# Altium schematic sheet Driver.SchDoc
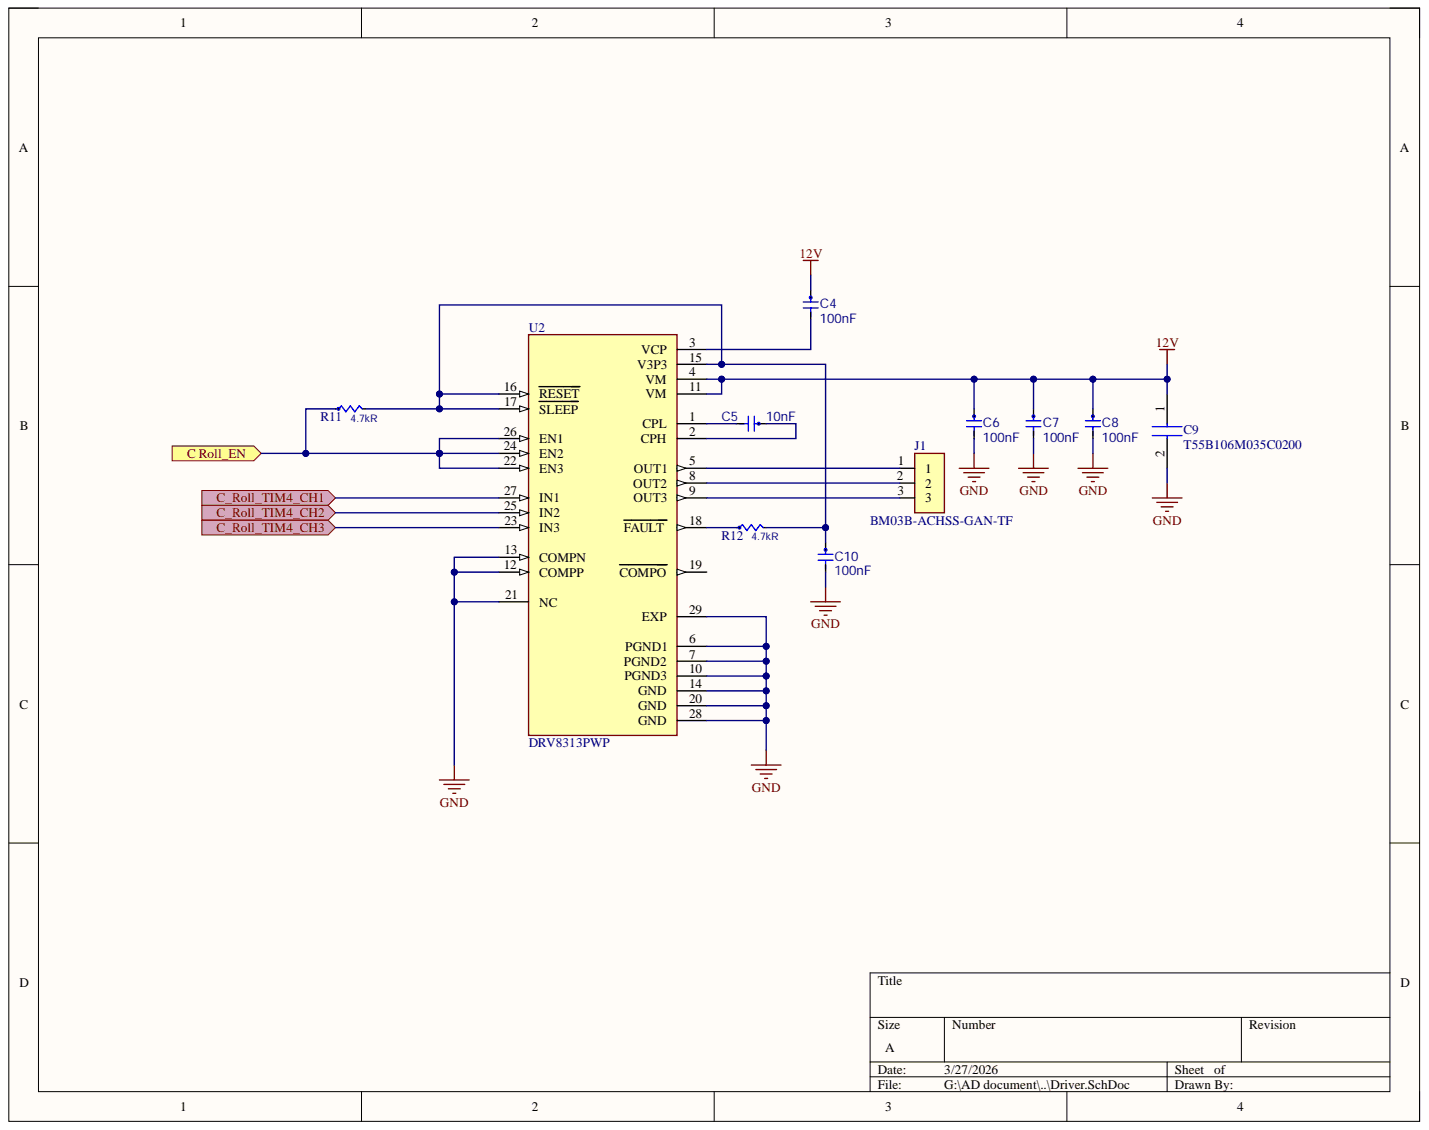
[diagram p0: Driver.SchDoc]

## Parts
C4  Capacitance: 100nF  [CAP0603]  (hidden comment: C0603C104M5RACTU)
C5  Capacitance: 10nF  [CAP0603]  (hidden comment: C0603C103M5RACTU)
C6  Capacitance: 100nF  [CAP0603]  (hidden comment: C0603C104M5RACTU)
C7  Capacitance: 100nF  [CAP0603]  (hidden comment: C0603C104M5RACTU)
C8  Capacitance: 100nF  [CAP0603]  (hidden comment: C0603C104M5RACTU)
C9  T55B106M035C0200  [T55B106M035C0200]
C10  Capacitance: 100nF  [CAP0603]  (hidden comment: C0603C104M5RACTU)
J1  BM03B-ACHSS-GAN-TF  [BM03B-ACHSS-GAN-TF]
R11  Resistance: 4.7kR  [RES0603]  (hidden comment: RC0603JR-074K7L)
R12  Resistance: 4.7kR  [RES0603]  (hidden comment: RC0603JR-074K7L)
U2  DRV8313PWP  [DRV8313PWP]

## Ports
C Roll_EN, C_Roll_TIM4_CH1, C_Roll_TIM4_CH2, C_Roll_TIM4_CH3

## Nets
12V: C4.1 C6.1 C7.1 C8.1 C9.1 U2.4(VM) U2.11(VM)
GND: C6.2 C7.2 C8.2 C9.2 C10.2 U2.6(PGND1) U2.7(PGND2) U2.10(PGND3) U2.12(COMPP) U2.13(COMPN) U2.14(GND) U2.20(GND) U2.21(NC) U2.28(GND) U2.29(EXP)
C Roll_EN: R11.1 U2.22(EN3) U2.24(EN2) U2.26(EN1) ; port C Roll_EN
C_Roll_TIM4_CH1: U2.27(IN1) ; port C_Roll_TIM4_CH1
C_Roll_TIM4_CH2: U2.25(IN2) ; port C_Roll_TIM4_CH2
C_Roll_TIM4_CH3: U2.23(IN3) ; port C_Roll_TIM4_CH3
NetC4_2: C4.2 U2.3(VCP)
NetC5_1: C5.1 U2.2(CPH)
NetC5_2: C5.2 U2.1(CPL)
NetC10_1: C10.1 R11.2 R12.2 U2.15(V3P3) U2.16(RESET) U2.17(SLEEP)
NetJ1_1: J1.1 U2.5(OUT1)
NetJ1_2: J1.2 U2.8(OUT2)
NetJ1_3: J1.3 U2.9(OUT3)
NetR12_1: R12.1 U2.18(FAULT)

Not connected: U2 19(COMPO)

## Library data of the parts
C4 C6-C8 C10 = CMP-00691-1: CAP CER 0.1UF 50V X7R 0603; Manufacturer 1: KEMET; Dielectric Material: Ceramic; Dissipation Factor: 2.5%; Tolerance: 20%; Case Code (Imperial): 0603; Case Code (Metric): 1608; Case/Package: 0603; Component Kind: Standard; Contact Plating: Tin,Matte; Depth: 0.8mm; Dielectric: X7R; Height: 0.8mm; Lead Pitch: 0.7mm; Length: 1.6mm; Manufacturer Part Number 1: C0603C104M5RACTU; Max Operating Temperature: 125°C; Min Operating Temperature: -55°C; Mount: Surface Mount,PCB; Package Quantity: 1; Packaging: Tape and Reel; Radiation Hardening: No; REACH SVHC: No SVHC; Termination: SMD/SMT; Thickness: 0.034inch; Voltage Rating: 50V; Voltage Rating (DC): 50V; Width: 0.031inch; Library Name: rtr.IntLib
C5 = CMP-00691-1: CAP CER 10NF 50V X7R 0603; Manufacturer 1: KEMET; Dielectric Material: Ceramic; Dissipation Factor: 2.5%; Tolerance: 20%; Case Code (Imperial): 0603; Case Code (Metric): 1608; Case/Package: 0603; Component Kind: Standard; Contact Plating: Tin,Matte; Depth: 0.8mm; Dielectric: X7R; Height: 0.8mm; Lead Pitch: 0.7mm; Length: 1.6mm; Manufacturer Part Number 1: C0603C103M5RACTU; Max Operating Temperature: 125°C; Min Operating Temperature: -55°C; Mount: Surface Mount,PCB; Package Quantity: 1; Packaging: Tape and Reel; Radiation Hardening: No; REACH SVHC: No SVHC; Termination: SMD/SMT; Thickness: 0.034inch; Voltage Rating: 50V; Voltage Rating (DC): 50V; Width: 0.031inch; Library Name: rtr.IntLib
C9 = T55B106M035C0200: Capacitor; Manufacturer_Name: Vishay; Arrow Part Number: T55B106M035C0200; Arrow Price/Stock: https://www.arrow.com/en/products/t55b106m035c0200/vishay; Component Kind: Standard; Datasheet Link: https://www.mouser.cn/datasheet/2/427/t55-537218.pdf; Height: 2.1mm; Library Name: T55B106M035C0200.IntLib; Manufacturer_Part_Number: T55B106M035C0200; Mouser Part Number: 74-T55B106M035C0200; Mouser Price/Stock: https://www.mouser.co.uk/ProductDetail/Vishay-Polytech/T55B106M035C0200?qs=BZBei1rCqCCB8UyqeipbyQ%3D%3D
J1 = BM03B-ACHSS-GAN-TF: ACH Series 3 Position 1.2 mm Pitch Disconnectable Crimp Style Housing; Availability: Unavailable; Component Kind: Standard; MP: BM03B-ACHSS-GAN-TF; Package: None; Price: None; Library Name: SM02B-SFKH-TF.IntLib
R11 R12 = CMP-01404-1: RES SMD 4.7K OHM 5% 1/10W 0603; Manufacturer 1: Yageo; Max Power Dissipation: 100mW; Temperature Coefficient: 100ppm/°C; Voltage Rating: 50V; Case Code (Imperial): 0603; Case Code (Metric): 1608; Case/Package: 0603; Component Kind: Standard; Construction: Rectangular; Depth: 0.8mm; Features: Moisture Resistant; Lead Free: Lead Free; Length: 1.6mm; Manufacturer Part Number 1: RC0603JR-074K7L; Max Operating Temperature: 155°C; Military Standard: Not; Min Operating Temperature: -55°C; Number of Pins: 2; Number of Terminations: 2; Packaging: Tape and Reel; Power Rating: 100mW; REACH SVHC: No SVHC; Resistance Tolerance: 5%; Resistor Type: Thick Film; Series: RC; Size Code: 0603; Termination: SMD/SMT; Tolerance: 5%; Voltage Rating (DC): 50V; Width: 0.8mm; Library Name: rtr.IntLib
U2 = DRV8313PWP: 65-V max, 3-A peak 3-phase motor driver; MF: Texas Instruments; Availability: In Stock; Check_prices: https://www.snapeda.com/parts/DRV8313PWP/Texas+Instruments/view-part/?ref=eda; Component Kind: Standard; MP: DRV8313PWP; Package: HTSSOP-28 Texas Instruments; Price: None; Purchase-URL: https://pricing.snapeda.com/search?q=DRV8313PWP&ref=eda; SnapEDA_Link: https://www.snapeda.com/parts/DRV8313PWP/Texas+Instruments/view-part/?ref=snap; Library Name: RTR.SchLib
